# Altium schematic sheet adc ext.SchDoc
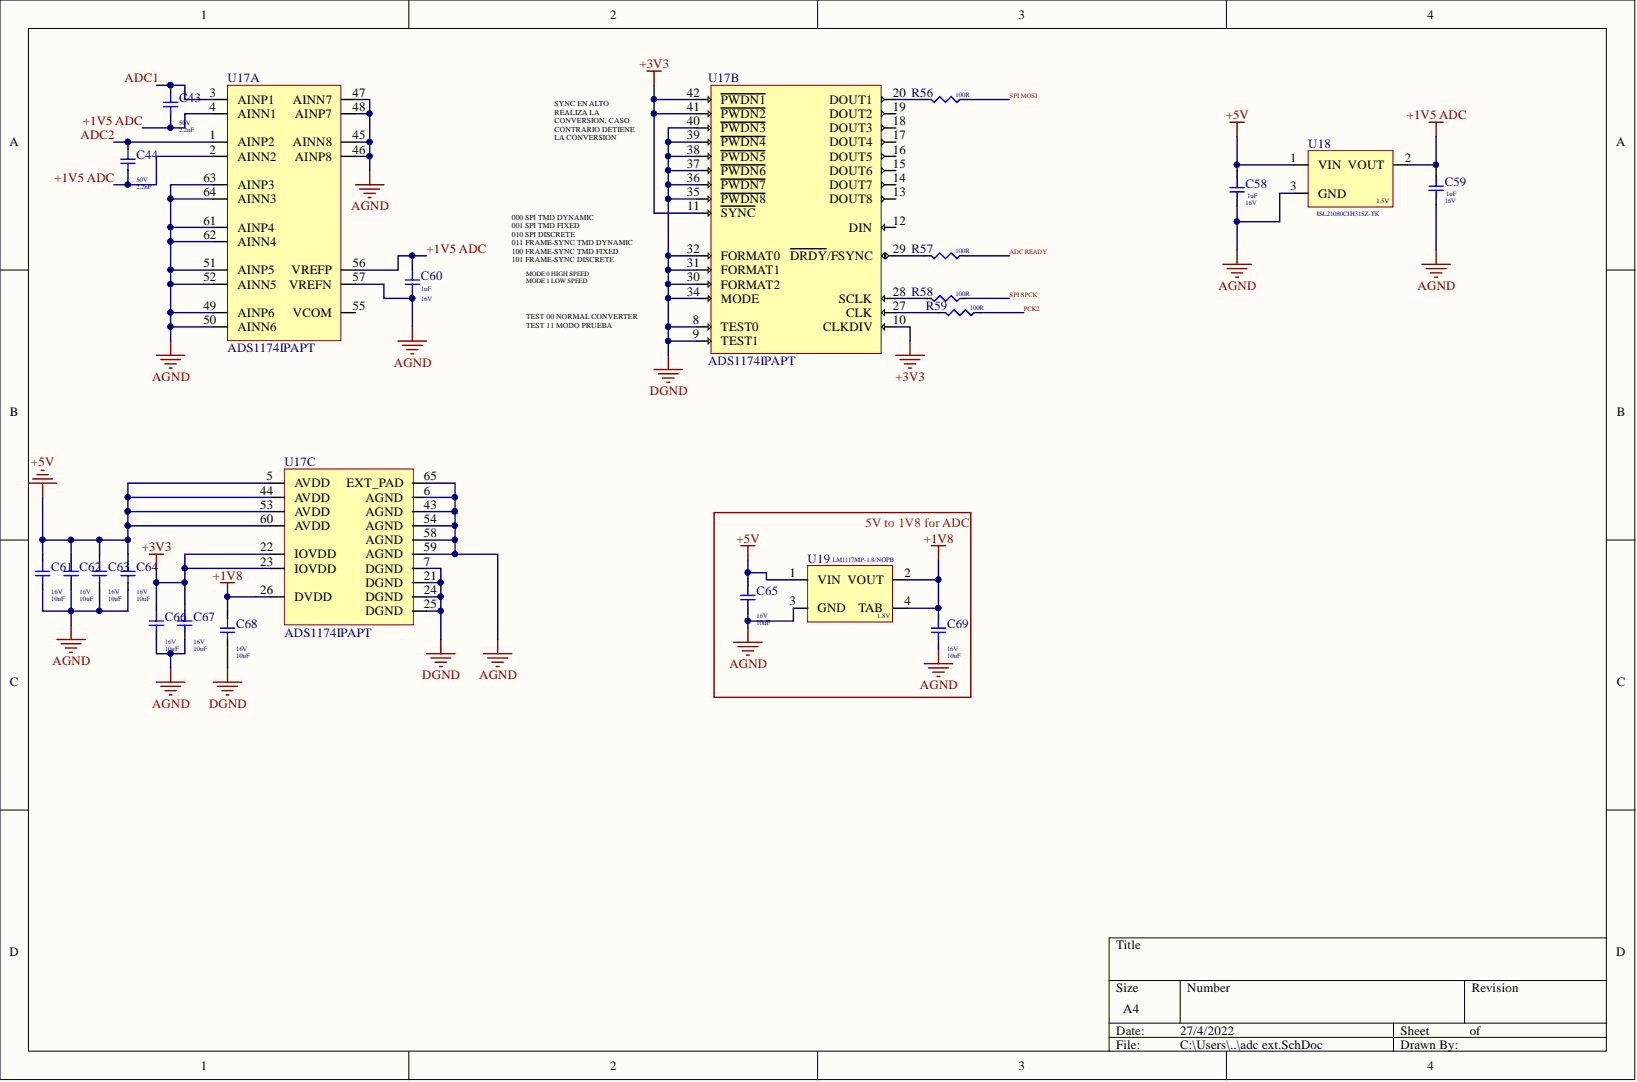
[diagram p0: adc ext.SchDoc]

## Parts
C43  Capacitance: 2.2nF  Voltage: 50V  [CAP smd 0805 OK]  (hidden comment: CAP_C0805C222K5RACTU)
C44  Capacitance: 2.2nF  Voltage: 50V  [CAP smd 0805 OK]  (hidden comment: CAP_C0805C222K5RACTU)
C58  Capacitance: 1uF  Voltage Rating: 16V  [CAP smd 0805 ok]  (hidden comment: CAP_CC0805KKX7R7BB105_YAGEO)
C59  Capacitance: 1uF  Voltage Rating: 16V  [CAP smd 0805 ok]  (hidden comment: CAP_CC0805KKX7R7BB105_YAGEO)
C60  Capacitance: 1uF  Voltage Rating: 16V  [CAP smd 0805 ok]  (hidden comment: CAP_CC0805KKX7R7BB105_YAGEO)
C61  Capacitance: 10uF  Voltage Rating: 16V  [CAP smd 0805 OK]  (hidden comment: CAP_CL21A106KOCL3RC)
C62  Capacitance: 10uF  Voltage Rating: 16V  [CAP smd 0805 OK]  (hidden comment: CAP_CL21A106KOCL3RC)
C63  Capacitance: 10uF  Voltage Rating: 16V  [CAP smd 0805 OK]  (hidden comment: CAP_CL21A106KOCL3RC)
C64  Capacitance: 10uF  Voltage Rating: 16V  [CAP smd 0805 OK]  (hidden comment: CAP_CL21A106KOCL3RC)
C65  Capacitance: 10uF  Voltage Rating: 16V  [CAP smd 0805 OK]  (hidden comment: CAP_CL21A106KOCL3RC)
C66  Capacitance: 10uF  Voltage Rating: 16V  [CAP smd 0805 OK]  (hidden comment: CAP_CL21A106KOCL3RC)
C67  Capacitance: 10uF  Voltage Rating: 16V  [CAP smd 0805 OK]  (hidden comment: CAP_CL21A106KOCL3RC)
C68  Capacitance: 10uF  Voltage Rating: 16V  [CAP smd 0805 OK]  (hidden comment: CAP_CL21A106KOCL3RC)
C69  Capacitance: 10uF  Voltage Rating: 16V  [CAP smd 0805 OK]  (hidden comment: CAP_CL21A106KOCL3RC)
R56  Resistance: 100R  [Res 1206 ok]  (hidden comment: RC1206JR-07100RL)
R57  Resistance: 100R  [Res 1206 ok]  (hidden comment: RC1206JR-07100RL)
R58  Resistance: 100R  [Res 1206 ok]  (hidden comment: RC1206JR-07100RL)
R59  Resistance: 100R  [Res 1206 ok]  (hidden comment: RC1206JR-07100RL)
U17  ADS1174IPAPT  [adc]  (parts A,B,C)
U18  ISL21080CIH315Z-TK  [iso 1.5v ok]
U19  LM1117MP-1.8/NOPB  Max Output Voltage: 1.8V  [1V8 ALIMENTACION]

## Nets
+1V8: C68.23 C69.23 U17.26(DVDD) U19.2(VOUT) U19.4(TAB)
+3V3: C66.23 C67.23 U17.10(CLKDIV) U17.11(SYNC) U17.22(IOVDD) U17.23(IOVDD) U17.41(PWDN2) U17.42(PWDN1)
+5V: C58.23 C61.23 C62.23 C63.23 C64.23 C65.23 U17.5(AVDD) U17.44(AVDD) U17.53(AVDD) U17.60(AVDD) U18.1 U19.1(VIN)
AGND: C58.22 C59.22 C60.22 C61.22 C62.22 C63.22 C64.22 C65.22 C66.22 C67.22 C69.22 U17.6(AGND) U17.43(AGND) U17.45(AINN8) U17.46(AINP8) U17.47(AINN7) U17.48(AINP7) U17.49(AINP6) U17.50(AINN6) U17.51(AINP5) U17.52(AINN5) U17.54(AGND) U17.57(VREFN) U17.58(AGND) U17.59(AGND) U17.61(AINP4) U17.62(AINN4) U17.63(AINP3) U17.64(AINN3) U17.65(EXT_PAD) U18.3 U19.3(GND)
DGND: C68.22 U17.7(DGND) U17.8(TEST0) U17.9(TEST1) U17.21(DGND) U17.24(DGND) U17.25(DGND) U17.30(FORMAT2) U17.31(FORMAT1) U17.32(FORMAT0) U17.34(MODE) U17.35(PWDN8) U17.36(PWDN7) U17.37(PWDN6) U17.38(PWDN5) U17.39(PWDN4) U17.40(PWDN3)
+1V5 ADC: C43.22 C44.22 C59.23 C60.23 U17.2(AINN2) U17.4(AINN1) U17.56(VREFP) U18.2
ADC1: C43.23 U17.3(AINP1)
ADC2: C44.23 U17.1(AINP2)
ADC READY: R57.2
PCK2: R59.2
SPI MOSI: R56.2
SPI SPCK: R58.2
NetR56_1: R56.1 U17.20(DOUT1)
NetR57_1: R57.1 U17.29(DRDY/FSYNC)
NetR58_1: R58.1 U17.28(SCLK)
NetR59_1: R59.1 U17.27(CLK)

Not connected: U17 12(DIN) 13(DOUT8) 14(DOUT7) 15(DOUT6) 16(DOUT5) 17(DOUT4) 18(DOUT3) 19(DOUT2) 55(VCOM)

## Library data of the parts
C43 C44 = CAP_C0805C222K5RACTU: Cap Ceramic 0.0022uF 50V X7R 10% SMD 0805 125°C Paper T/R; Manufacturer 1: KEMET; Dissipation Factor: 2.5%; Case/Package: 0805; Case Code (Imperial): 0805; Case Code (Metric): 2012; Component Kind: Standard; ComponentLink1Description: https://datasheet.ciiva.com/28584/kem-c1002-x7r-smd-28584143.pdf?src-supplier=Element14; ComponentLink1URL: https://datasheet.ciiva.com/28584/kem-c1002-x7r-smd-28584143.pdf?src-supplier=Element14; ComponentLink2Description: https://datasheet.ciiva.com/28692/1851736-28692973.pdf?src-supplier=Element14; ComponentLink2URL: https://datasheet.ciiva.com/28692/1851736-28692973.pdf?src-supplier=Element14; Construction: Flat; Depth: 1.25mm; Dielectric: X7R; Dielectric Material: Ceramic; Height: 0.78mm; Lead Pitch: 0.75mm; Length: 2mm; Library Name: Cap.SchLib; Manufacturer Part Number 1: C0805C222K5RACTU; Max Operating Temperature: 125°C; Min Operating Temperature: -55°C; Mount: Surface Mount,PCB; Number of Pins: 2; Package Quantity: 1; Packaging: Tape and Reel; Pricing 1: 1=0,10000, 10=0,05400, 50=0,02960, 100=0,02420, 500=0,01730, 1000=0,01360 (USD); Radiation Hardening: No; REACH SVHC: No SVHC; RoHS: Yes; RoHS Compliant: Yes; Series: C; Stock 1: 26149; Supplier 1: Digi-Key; Supplier Part Number 1: 399-1151-1-ND; Termination: SMD/SMT; Thickness: 0.035inch; Tolerance: 10%; Voltage Rating: 50V; Voltage Rating (DC): 50V; Width: 0.049inch
C58-C60 = CAP_CC0805KKX7R7BB105_YAGEO: YAGEO   CC0805KKX7R7BB105   CERAMIC CAPACITOR, 1UF, 16V, X7R, 10%, 0805; Case/Package: 0805; Case Code (Imperial): 0805; Case Code (Metric): 2012; Component Kind: Standard; ComponentLink1Description: https://datasheet.ciiva.com/144/mlccorderingcode-144902.pdf?src-supplier=Digi-Key; ComponentLink1URL: https://datasheet.ciiva.com/144/mlccorderingcode-144902.pdf?src-supplier=Digi-Key; ComponentLink2Description: https://datasheet.ciiva.com/144/mlccorderingcode-144903.pdf?src-supplier=Digi-Key; ComponentLink2URL: https://datasheet.ciiva.com/144/mlccorderingcode-144903.pdf?src-supplier=Digi-Key; ComponentLink3Description: https://datasheet.ciiva.com/144/mlccorderingcode-144904.pdf?src-supplier=Digi-Key; ComponentLink3URL: https://datasheet.ciiva.com/144/mlccorderingcode-144904.pdf?src-supplier=Digi-Key; ComponentLink4Description: https://datasheet.ciiva.com/11464/upy-gphc-x7r-6-3v-to-50v-8-11464750.pdf?src-supplier=Avnet; ComponentLink4URL: https://datasheet.ciiva.com/11464/upy-gphc-x7r-6-3v-to-50v-8-11464750.pdf?src-supplier=Avnet; ComponentLink5Description: https://datasheet.ciiva.com/13173/upy-gp-np0-16v-to-50v-8-3714-13173254.pdf?src-supplier=Mouser; ComponentLink5URL: https://datasheet.ciiva.com/13173/upy-gp-np0-16v-to-50v-8-3714-13173254.pdf?src-supplier=Mouser; ComponentLink6Description: https://datasheet.ciiva.com/7978/1489800-7978201.pdf?src-supplier=Element14; ComponentLink6URL: https://datasheet.ciiva.com/7978/1489800-7978201.pdf?src-supplier=Element14; ComponentLink7Description: https://datasheet.ciiva.com/28450/1489800-28450750.pdf?src-supplier=Element14; ComponentLink7URL: https://datasheet.ciiva.com/28450/1489800-28450750.pdf?src-supplier=Element14; ComponentLink8Description: https://datasheet.ciiva.com/15189/getdatasheetpartid-251802-15189206.pdf?src-supplier=Verical; ComponentLink8URL: https://datasheet.ciiva.com/15189/getdatasheetpartid-251802-15189206.pdf?src-supplier=Verical; Depth: 1.25mm; Dielectric: X7R; Halogen Free: Halogen Free; Lead Free: Lead Free; Length: 2mm; Library Name: Cap.SchLib; Manufacturer 1: Yageo; Manufacturer Part Number 1: CC0805KKX7R7BB105; Max Operating Temperature: 125°C; Min Operating Temperature: -55°C; Mount: Surface Mount; Package Quantity: 1; Packaging: Tape and Reel; Pricing 1: 1=0,16000, 10=0,11700, 50=0,06640, 100=0,05500, 500=0,03858, 1000=0,03194 (USD); Radiation Hardening: No; REACH SVHC: No SVHC; RoHS: Yes; RoHS Compliant: Yes; Series: CC; Stock 1: 516899; Supplier 1: Digi-Key; Supplier Part Number 1: 311-1365-1-ND; Termination: SMD/SMT; Thickness: 0.049inch; Tolerance: 10%; Voltage Rating (DC): 16V; Width: 0.049inch
C61-C69 = CAP_CL21A106KOCL3RC: CAP CER 10UF 16V X5R 0805; Manufacturer 1: Samsung; Tolerance: 10%; Case/Package: 0805; Case Code (Imperial): 0805; Case Code (Metric): 2012; Component Kind: Standard; ComponentLink1Description: https://datasheet.ciiva.com/25796/cl21a106kocl3rc-25796224.pdf?src-supplier=Digi-Key; ComponentLink1URL: https://datasheet.ciiva.com/25796/cl21a106kocl3rc-25796224.pdf?src-supplier=Digi-Key; Length: 0.079inch; Library Name: Cap.SchLib; Manufacturer Part Number 1: CL21A106KOCL3RC; Max Operating Temperature: 85°C; Min Operating Temperature: -55°C; Mount: Surface Mount; Package Quantity: 1; Packaging: Tape and Reel; RoHS: Yes; RoHS Compliant: Yes; Series: CL; Stock 1: 0; Supplier 1: Digi-Key; Supplier Part Number 1: 1276-2398-2-ND; Thickness: 0.037inch; Width: 0.049inch
R56-R59 = RC1206JR-07100RL: Thick Film Resistors SMD 1206 (3264)  100 OHM 5% 250mW; Manufacturer 1: Yageo; Temperature Coefficient: 100ppm/°C; Voltage Rating: 200V; Case/Package: 1206; Case Code (Imperial): 1206; Case Code (Metric): 3216; Component Kind: Standard; ComponentLink1Description: https://datasheet.ciiva.com/29181/402427-da-01-en-smd-widerstand-chip1206-100-ohm-29181432.pdf?src-supplier=Conrad; ComponentLink1URL: https://datasheet.ciiva.com/29181/402427-da-01-en-smd-widerstand-chip1206-100-ohm-29181432.pdf?src-supplier=Conrad; ComponentLink2Description: https://datasheet.ciiva.com/2458/pyu-rc1206-51-rohs-l-4-2458710.pdf?src-supplier=Digi-Key; ComponentLink2URL: https://datasheet.ciiva.com/2458/pyu-rc1206-51-rohs-l-4-2458710.pdf?src-supplier=Digi-Key; ComponentLink3Description: https://datasheet.ciiva.com/2458/pyu-rc1206-51-rohs-l-4-2458711.pdf?src-supplier=Digi-Key; ComponentLink3URL: https://datasheet.ciiva.com/2458/pyu-rc1206-51-rohs-l-4-2458711.pdf?src-supplier=Digi-Key; ComponentLink4Description: https://datasheet.ciiva.com/2458/pyu-rc1206-51-rohs-l-4-2458712.pdf?src-supplier=Digi-Key; ComponentLink4URL: https://datasheet.ciiva.com/2458/pyu-rc1206-51-rohs-l-4-2458712.pdf?src-supplier=Digi-Key; ComponentLink5Description: https://datasheet.ciiva.com/16772/pyu-rc1206-51-rohs-l-4-16772235.pdf?src-supplier=Future+Electronics; ComponentLink5URL: https://datasheet.ciiva.com/16772/pyu-rc1206-51-rohs-l-4-16772235.pdf?src-supplier=Future+Electronics; Construction: Rectangular; Depth: 1.6mm; Height: 0.026inch; Lead Free: Lead Free; Length: 0.122inch; Library Name: res.SchLib; Manufacturer Part Number 1: RC1206JR-07100RL; Max Operating Temperature: 155°C; Max Power Dissipation: 250mW; Military Standard: Not; Min Operating Temperature: -55°C; Number of Terminations: 2; Package Quantity: 5000; Packaging: Tape and Reel; Power Rating: 250mW; Pricing 1: 1=0,10000, 10=0,06300, 100=0,02580, 1000=0,01158, 2500=0,01005 (USD); REACH SVHC: Unknown; Resistance Tolerance: 5%; Resistor Type: Thick Film; RoHS: Yes; RoHS Compliant: Yes; Series: RC1206; Size Code: 1206; Stock 1: 1229853; Supplier 1: Digi-Key; Supplier Part Number 1: 311-100ERCT-ND; Termination: SMD/SMT; Tolerance: 5%; Width: 0.063inch
U17 = ADS1174IPAPT: Octal Channel Quad ADC Delta-Sigma 52ksps 16-bit Serial 64-Pin HTQFP EP T/R; Manufacturer 1: Texas Instruments; Conversion Rate: 52KS/s; Differential Nonlinearity: 1LSB; Input Type: SINGLE-ENDED; Interface: SPI; Max Power Dissipation: 210mW; Max Supply Voltage: 5.25V; Min Supply Voltage: 4.75V; Nominal Supply Current: 23mA; Number of A/D Converters: 4; Number of Analog Inputs: 8; Number of Bits: 16; Operating Supply Voltage: 5V; Polarity: Bipolar; Power Consumption: 135mW; Resolution: 16b; Signal to Noise Ratio (SNR): 97dB; Supply Type: Analog,Digital; Case/Package: HTQFP; Component Kind: Standard; ComponentLink1Description: https://datasheet.ciiva.com/7226/46094-7226797.pdf?src-supplier=Newark; ComponentLink1URL: https://datasheet.ciiva.com/7226/46094-7226797.pdf?src-supplier=Newark; ComponentLink2Description: https://datasheet.ciiva.com/41461/001186699-da-01-en-ic-adc-16bit-q-ads1174ipapt-htqfp-64-tid-41461041.pdf?src-supplier=Conrad; ComponentLink2URL: https://datasheet.ciiva.com/41461/001186699-da-01-en-ic-adc-16bit-q-ads1174ipapt-htqfp-64-tid-41461041.pdf?src-supplier=Conrad; ComponentLink3Description: https://datasheet.ciiva.com/26800/ads1174ipapt-26800957.pdf?src-supplier=IIIC; ComponentLink3URL: https://datasheet.ciiva.com/26800/ads1174ipapt-26800957.pdf?src-supplier=IIIC; ComponentLink4Description: https://datasheet.ciiva.com/1704/1831206-1704289.pdf?src-supplier=Element14; ComponentLink4URL: https://datasheet.ciiva.com/1704/1831206-1704289.pdf?src-supplier=Element14; ComponentLink5Description: https://datasheet.ciiva.com/1264/ads1174-1264637.pdf?src-supplier=Digi-Key; ComponentLink5URL: https://datasheet.ciiva.com/1264/ads1174-1264637.pdf?src-supplier=Digi-Key; ComponentLink6Description: https://datasheet.ciiva.com/1264/ads1174-1264636.pdf?src-supplier=Digi-Key; ComponentLink6URL: https://datasheet.ciiva.com/1264/ads1174-1264636.pdf?src-supplier=Digi-Key; ComponentLink7Description: https://datasheet.ciiva.com/1264/ads1174-1264638.pdf?src-supplier=Digi-Key; ComponentLink7URL: https://datasheet.ciiva.com/1264/ads1174-1264638.pdf?src-supplier=Digi-Key; ComponentLink8Description: https://datasheet.ciiva.com/14257/sbas373b-14257851.pdf?src-supplier=Avnet; ComponentLink8URL: https://datasheet.ciiva.com/14257/sbas373b-14257851.pdf?src-supplier=Avnet; Contact Plating: Gold; Height: 1.05mm; Integral Nonlinearity (INL): 1LSB; Lead Free: Lead Free; Length: 10.2mm; Library Name: ic.SchLib; Manufacturer Part Number 1: ADS1174IPAPT; Max Operating Temperature: 85°C; Min Operating Temperature: -40°C; Mount: Surface Mount; Number of Channels: 4; Number of Converters: 4; Number of Elements: 4; Number of Inputs: 4; Number of Pins: 64; Package Quantity: 250; Packaging: Tape and Reel; Power Dissipation: 135mW; Pricing 1: 1=16,29000, 10=14,97100, 25=14,35040, 100=12,80650 (USD); REACH SVHC: No SVHC; RoHS: Yes; RoHS Compliant: Yes; Sampling Rate: 52KS/s; Stock 1: 229; Supplier 1: Digi-Key; Supplier Part Number 1: 296-22951-1-ND; Supply Current: 23mA; Termination: SMD/SMT; Width: 10.2mm
U18 = ISL21080CIH315Z-TK: ISL21080 Series 1.5 V 0.5% 300nA NanoPower Voltage Reference - SOT-23-3; Manufacturer 1: Renesas; Component Kind: Standard; ComponentLink1Description: http://www.farnell.com/datasheets/2354212.pdf?src-supplier=Farnell; ComponentLink1URL: http://www.farnell.com/datasheets/2354212.pdf?src-supplier=Farnell; ComponentLink2Description: http://www.farnell.com/datasheets/2354212.pdf?src-supplier=Newark; ComponentLink2URL: http://www.farnell.com/datasheets/2354212.pdf?src-supplier=Newark; ComponentLink3Description: http://www.farnell.com/datasheets/2354212.pdf?src-supplier=Element14; ComponentLink3URL: http://www.farnell.com/datasheets/2354212.pdf?src-supplier=Element14; ComponentLink4Description: http://www.farnell.com/datasheets/1492089.pdf?src-supplier=Newark; ComponentLink4URL: http://www.farnell.com/datasheets/1492089.pdf?src-supplier=Newark; Library Name: ic.SchLib; Manufacturer Part Number 1: ISL21080CIH315Z-TK; Pricing 1: 1=1,66000, 10=1,48900, 25=1,40440, 100=1,19660, 250=1,12360, 500=0,98316 (USD); RoHS: Yes; RoHS Compliant: Yes; Stock 1: 1020; Supplier 1: Digi-Key; Supplier Part Number 1: ISL21080CIH315Z-TKCT-ND
U19 = LM1117MP-1.8/NOPB: Fixed Positive LDO Regulator, 1.8V, 1.3V Dropout, BIPolar, PDSO4; Manufacturer 1: TI National Semiconductor; Accuracy: 1%; Current Rating: 800A; Dropout Voltage: 1.2V; Max Input Voltage: 15V; Max Output Current: 800mA; Max Supply Current: 10mA; Min Input Voltage: 2.6V; Number of Outputs: 1; Number of Terminals/Pins: 4; Power Supply Rejection Ratio (PSRR): 75dB; Quiescent Current: 5mA; Case/Package: SOT-223; Component Kind: Standard; ComponentLink1Description: https://datasheet.ciiva.com/34302/1282062-34302143.pdf?src-supplier=Jameco; ComponentLink1URL: https://datasheet.ciiva.com/34302/1282062-34302143.pdf?src-supplier=Jameco; ComponentLink2Description: https://datasheet.ciiva.com/26904/lm1117mp-1-8-nopb-26904877.pdf?src-supplier=IIIC; ComponentLink2URL: https://datasheet.ciiva.com/26904/lm1117mp-1-8-nopb-26904877.pdf?src-supplier=IIIC; ComponentLink3Description: https://datasheet.ciiva.com/2047/lm1117-n-2047309.pdf?src-supplier=Digi-Key; ComponentLink3URL: https://datasheet.ciiva.com/2047/lm1117-n-2047309.pdf?src-supplier=Digi-Key; ComponentLink4Description: https://datasheet.ciiva.com/2047/lm1117-n-2047310.pdf?src-supplier=Digi-Key; ComponentLink4URL: https://datasheet.ciiva.com/2047/lm1117-n-2047310.pdf?src-supplier=Digi-Key; ComponentLink5Description: https://datasheet.ciiva.com/2047/lm1117-n-2047311.pdf?src-supplier=Digi-Key; ComponentLink5URL: https://datasheet.ciiva.com/2047/lm1117-n-2047311.pdf?src-supplier=Digi-Key; ComponentLink6Description: https://datasheet.ciiva.com/1570/1849796-1570200.pdf?src-supplier=Farnell; ComponentLink6URL: https://datasheet.ciiva.com/1570/1849796-1570200.pdf?src-supplier=Farnell; ComponentLink7Description: https://datasheet.ciiva.com/8042/10195-8042269.pdf?src-supplier=Element14; ComponentLink7URL: https://datasheet.ciiva.com/8042/10195-8042269.pdf?src-supplier=Element14; ComponentLink8Description: https://datasheet.ciiva.com/25980/lm1117-n-25980605.pdf?src-supplier=Avnet+Europe; ComponentLink8URL: https://datasheet.ciiva.com/25980/lm1117-n-25980605.pdf?src-supplier=Avnet+Europe; ComponentLink9Description: https://datasheet.ciiva.com/14149/lm1117-eng-tds-14149978.pdf?src-supplier=Distrelec; ComponentLink9URL: https://datasheet.ciiva.com/14149/lm1117-eng-tds-14149978.pdf?src-supplier=Distrelec; Contact Plating: Tin,Matte; Height: 1.6mm; Lead Free: Lead Free; Length: 6.5mm; Library Name: ic.SchLib; Manufacturer Part Number 1: LM1117MP-1.8/NOPB; Max Operating Temperature: 125°C; Min Operating Temperature: 0°C; Moisture Sensitivity Level: 1; Mount: Surface Mount; Nominal Output Voltage: 1.8V; Number of Pins: 4; Number of Regulators: 1; Output Configuration: Positive; Output Current: 800mA; Output Type: Fixed; Output Voltage: 1.8V; Output Voltage Accuracy: 1%; Package Quantity: 1000; Packaging: Tape and Reel; Polarity: Positive; Pricing 1: 1=1,41000, 10=1,26300, 25=1,19920, 100=0,98500, 250=0,92072, 500=0,81366 (USD); Radiation Hardening: No; REACH SVHC: No SVHC; RoHS: Yes; Series: -; Stock 1: 994; Supplier 1: Digi-Key; Supplier Part Number 1: LM1117MP-1.8/NOPBCT-ND; Terminal Finish: Tin; Terminal Form: SMD/SMT; Termination: SMD/SMT; Width: 3.56mm
